# Altium schematic sheet Fuel-Gauge.SchDoc(U_Fuel-Gauge)
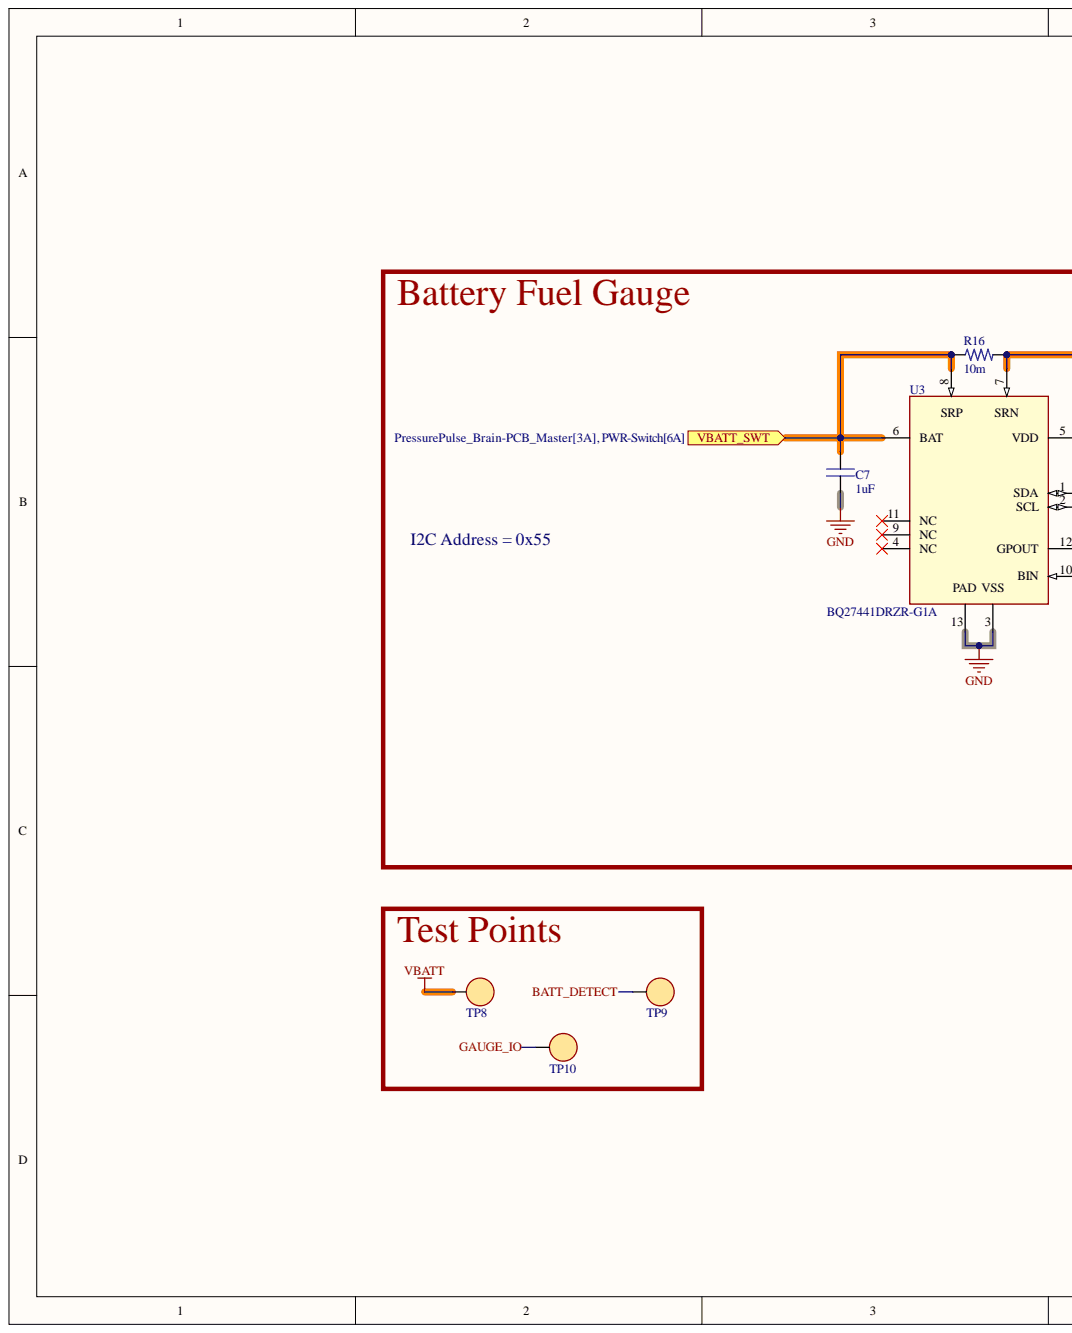
[diagram p0: Fuel-Gauge.SchDoc, left]
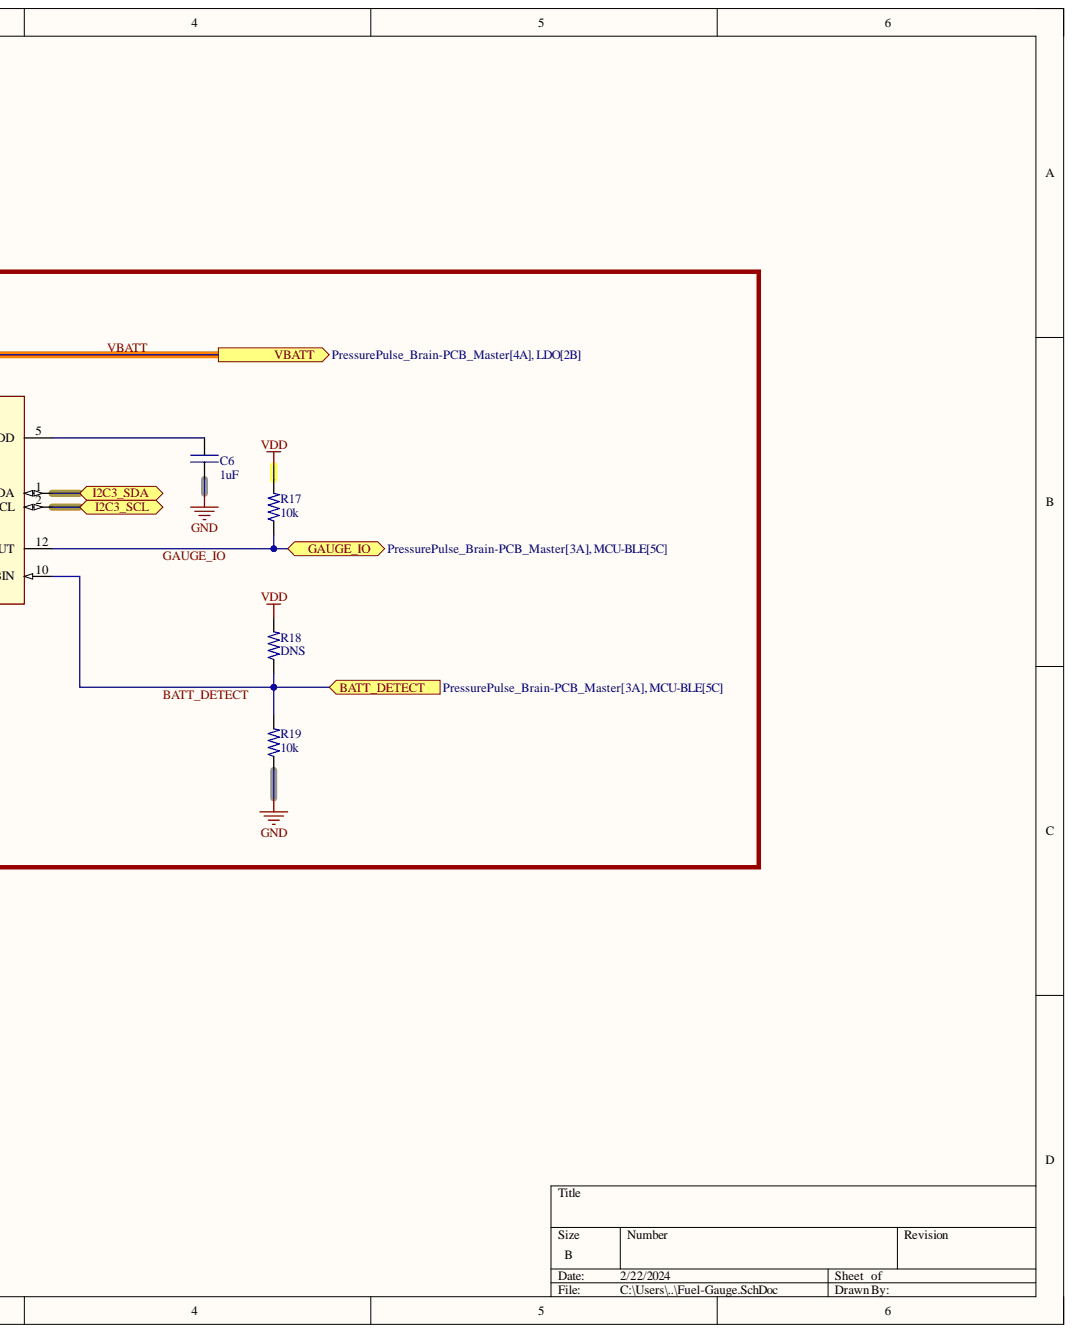
[diagram p1: Fuel-Gauge.SchDoc, right]

## Parts
C6  value 1uF  (hidden comment: CAP)
C7  value 1uF  (hidden comment: CAP)
R16  value 10m  [2512R]  (hidden comment: RES - CURRENT SENSE)
R17  value 10k  (hidden comment: RES)
R18  value DNS  (hidden comment: RES)
R19  value 10k  (hidden comment: RES)
TP8  RH-5019  [TP - RH-5019]  (value not drawn)
TP9  RH-5019  [TP - RH-5019]  (value not drawn)
TP10  RH-5019  [TP - RH-5019]  (value not drawn)
U3  BQ27441DRZR-G1A  [bq27441-G1]

## Ports
BATT_DETECT, GAUGE_IO, I2C3_SCL, I2C3_SDA, VBATT, VBATT_SWT

## Nets
GND: C6.1 C7.1 R19.1 U3.3 U3.13
VDD: R17.2 R18.2
BATT_DETECT: R18.1 R19.2 TP9.1 U3.10(BIN) ; port BATT_DETECT
GAUGE_IO: R17.1 TP10.1 U3.12(GPOUT) ; port GAUGE_IO
I2C3_SCL: U3.2(SCL) ; port I2C3_SCL
I2C3_SDA: U3.1(SDA) ; port I2C3_SDA
VBATT: R16.2 TP8.1 U3.7 ; port VBATT
VBATT_SWT: C7.2 R16.1 U3.6(BAT) U3.8 ; port VBATT_SWT
NetC6_2: C6.2 U3.5(VDD)
NetU3_4: U3.4(NC)
NetU3_9: U3.9(NC)
NetU3_11: U3.11(NC)

## Library data of the parts
C6 C7 = CAP: Capacitor; Component Kind: Standard; Library Name: PressurePulse.SchLib
R16 = RES - CURRENT SENSE: 3W 10m Ohm Current Sense Resistor; Tolerance: 1%; Component Kind: Standard; JLCPCB PN: C429515; Library Name: PressurePulse.SchLib
R17-R19 = RES: Resistor; Component Kind: Standard; Library Name: PressurePulse.SchLib
TP8-TP10 = TEST POINT: MFR: ronghe; Component Kind: Standard; JLCPCB PN: C5199800; Library Name: PressurePulse.SchLib; MFR PN: RH-5019; Value: RH-5019
U3 = BATT FUEL GAUGE: No Description Available; MFR: Texas Instruments; Component Kind: Standard; JLCPCB PN: C473397; Library Name: PressurePulse.SchLib; MFR PN: BQ27441DRZR-G1A; Value: BQ27441DRZR-G1A
